# Altium schematic sheet 09 NET.SchDoc
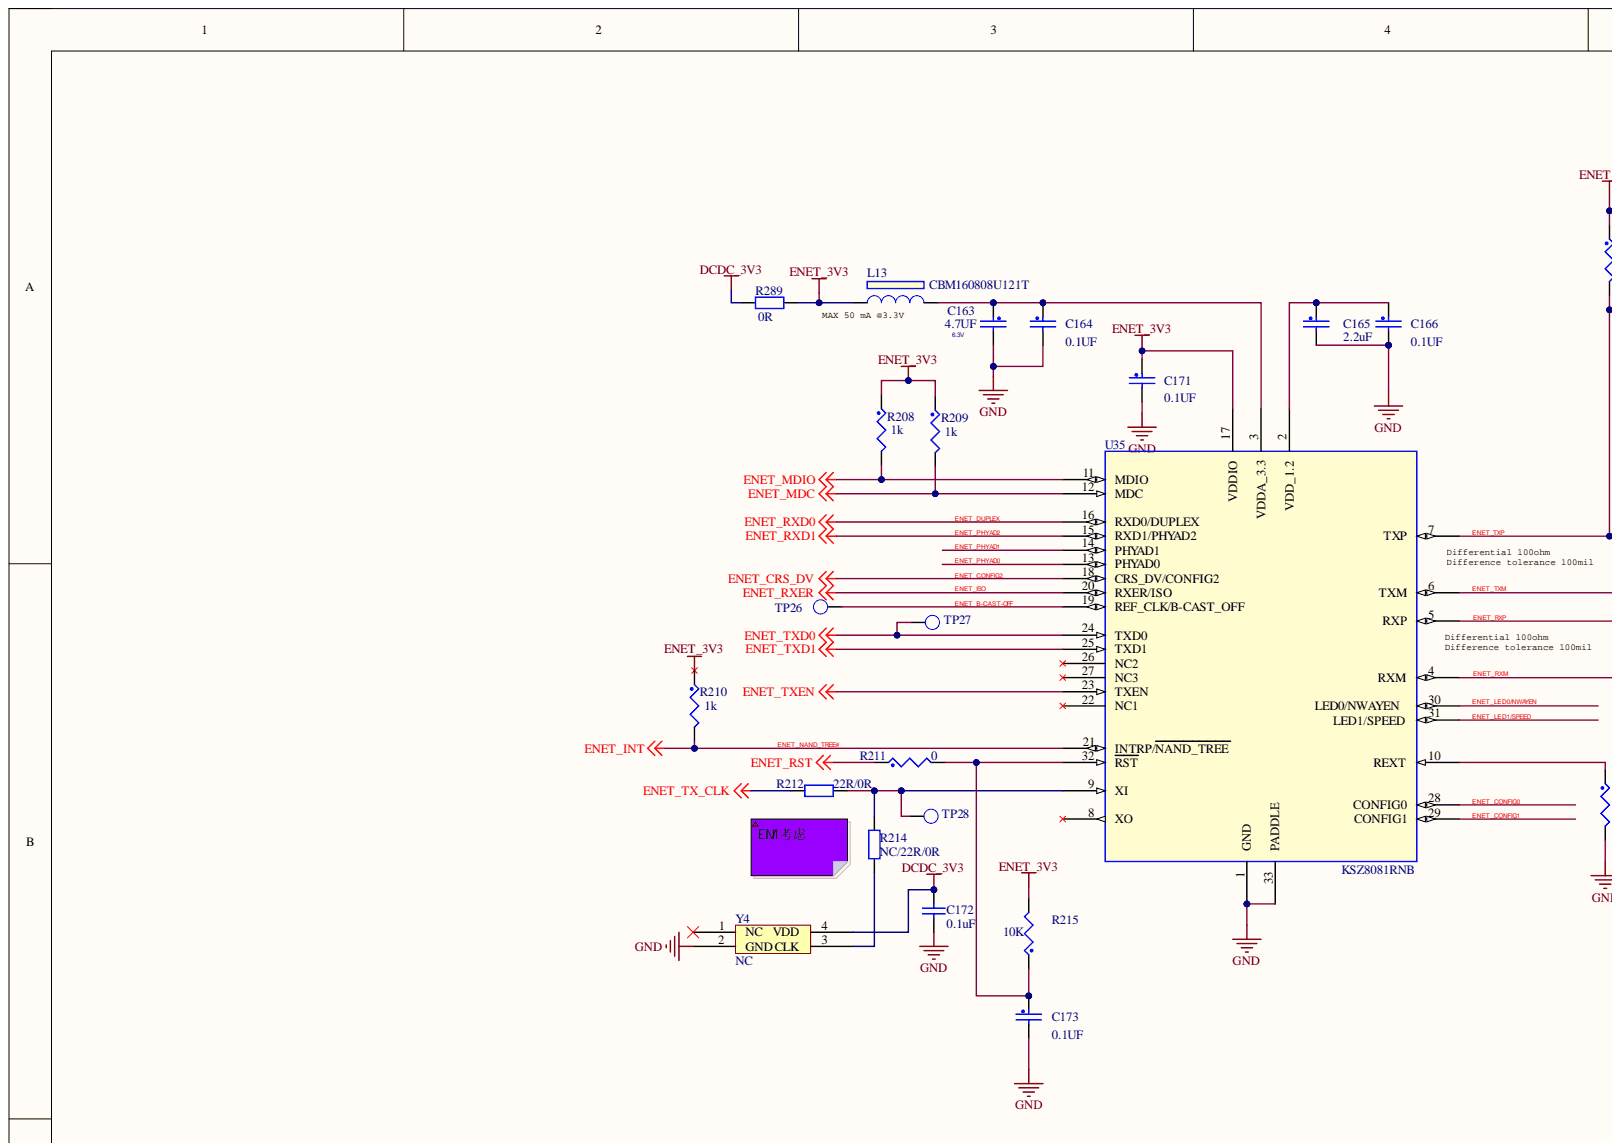
[diagram p0: 09 NET.SchDoc, top left]
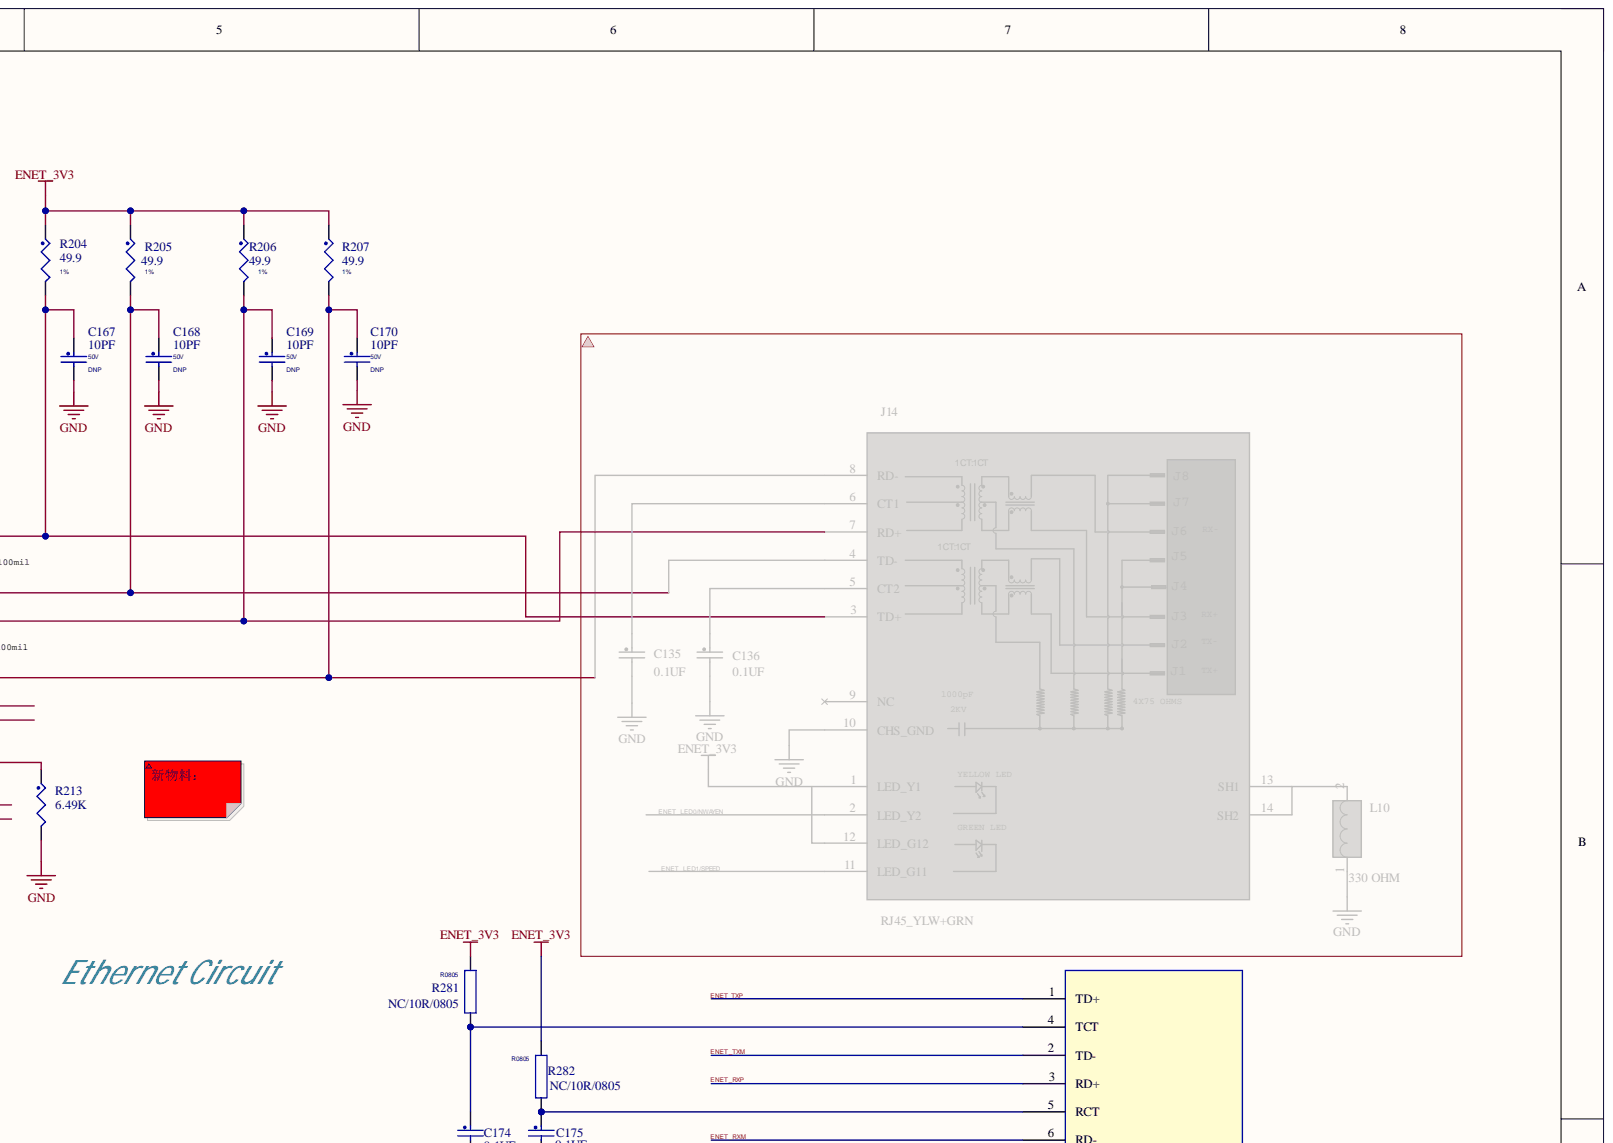
[diagram p1: 09 NET.SchDoc, top right]
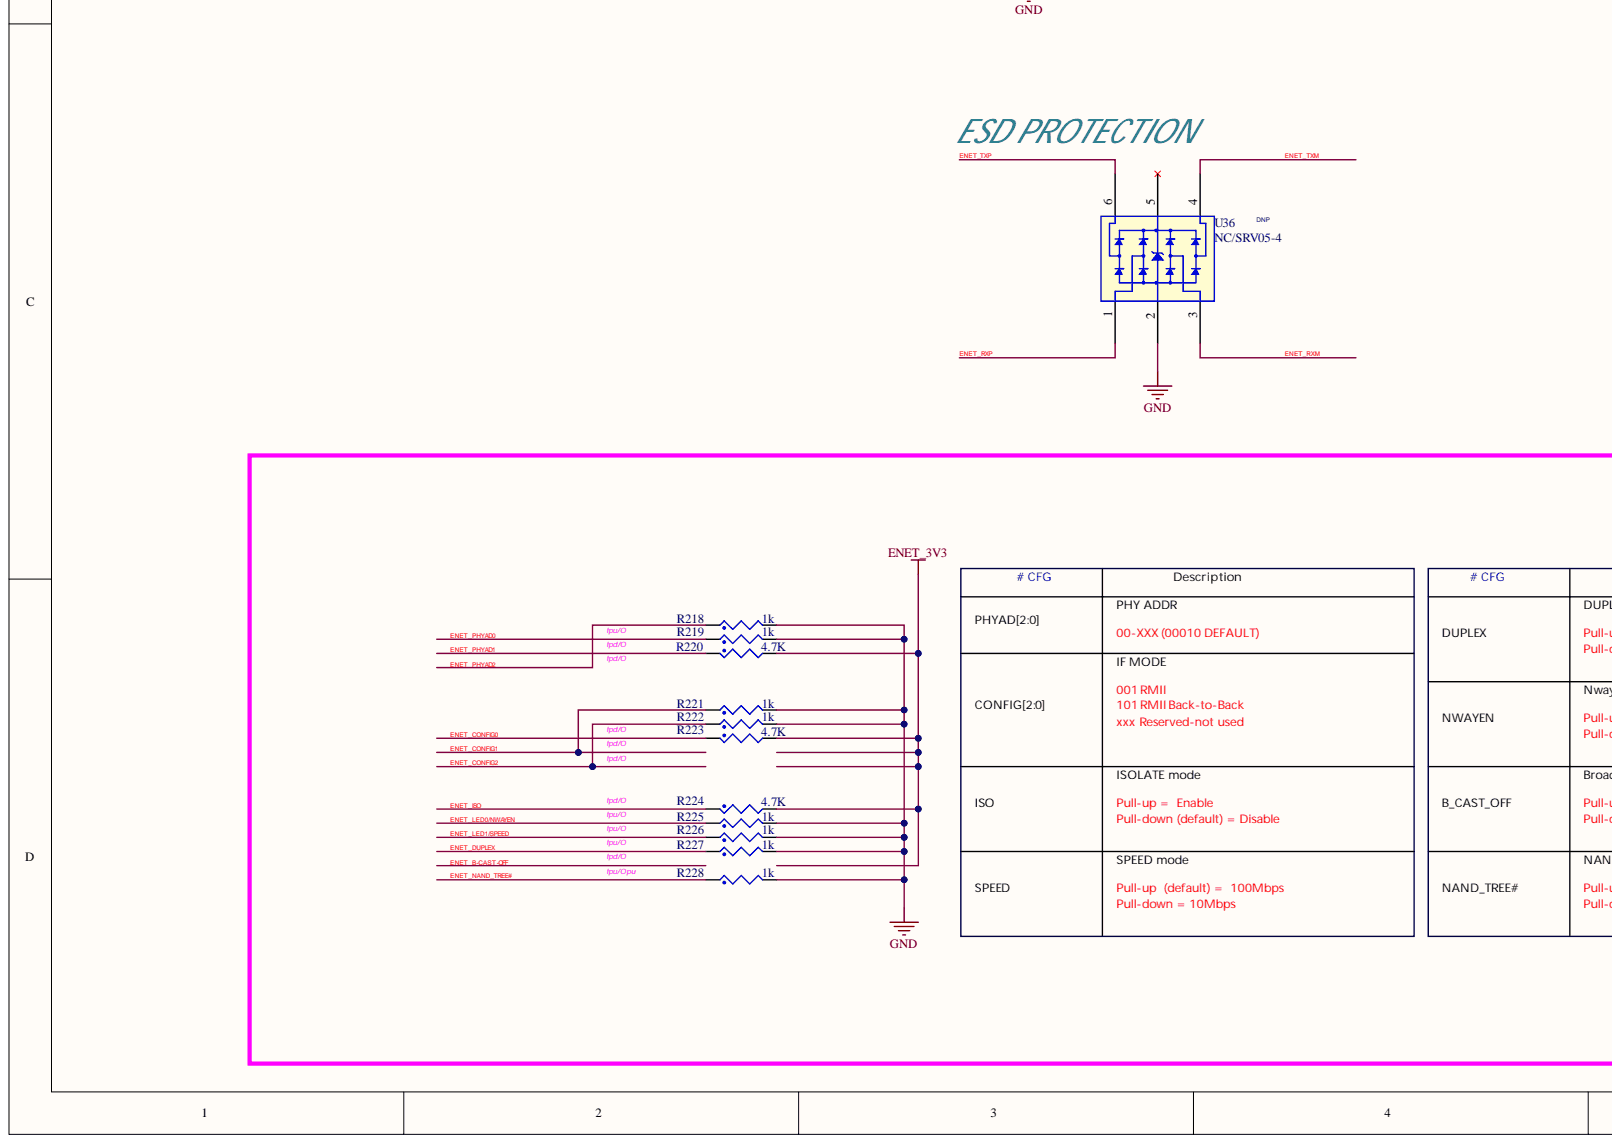
[diagram p2: 09 NET.SchDoc, bottom left]
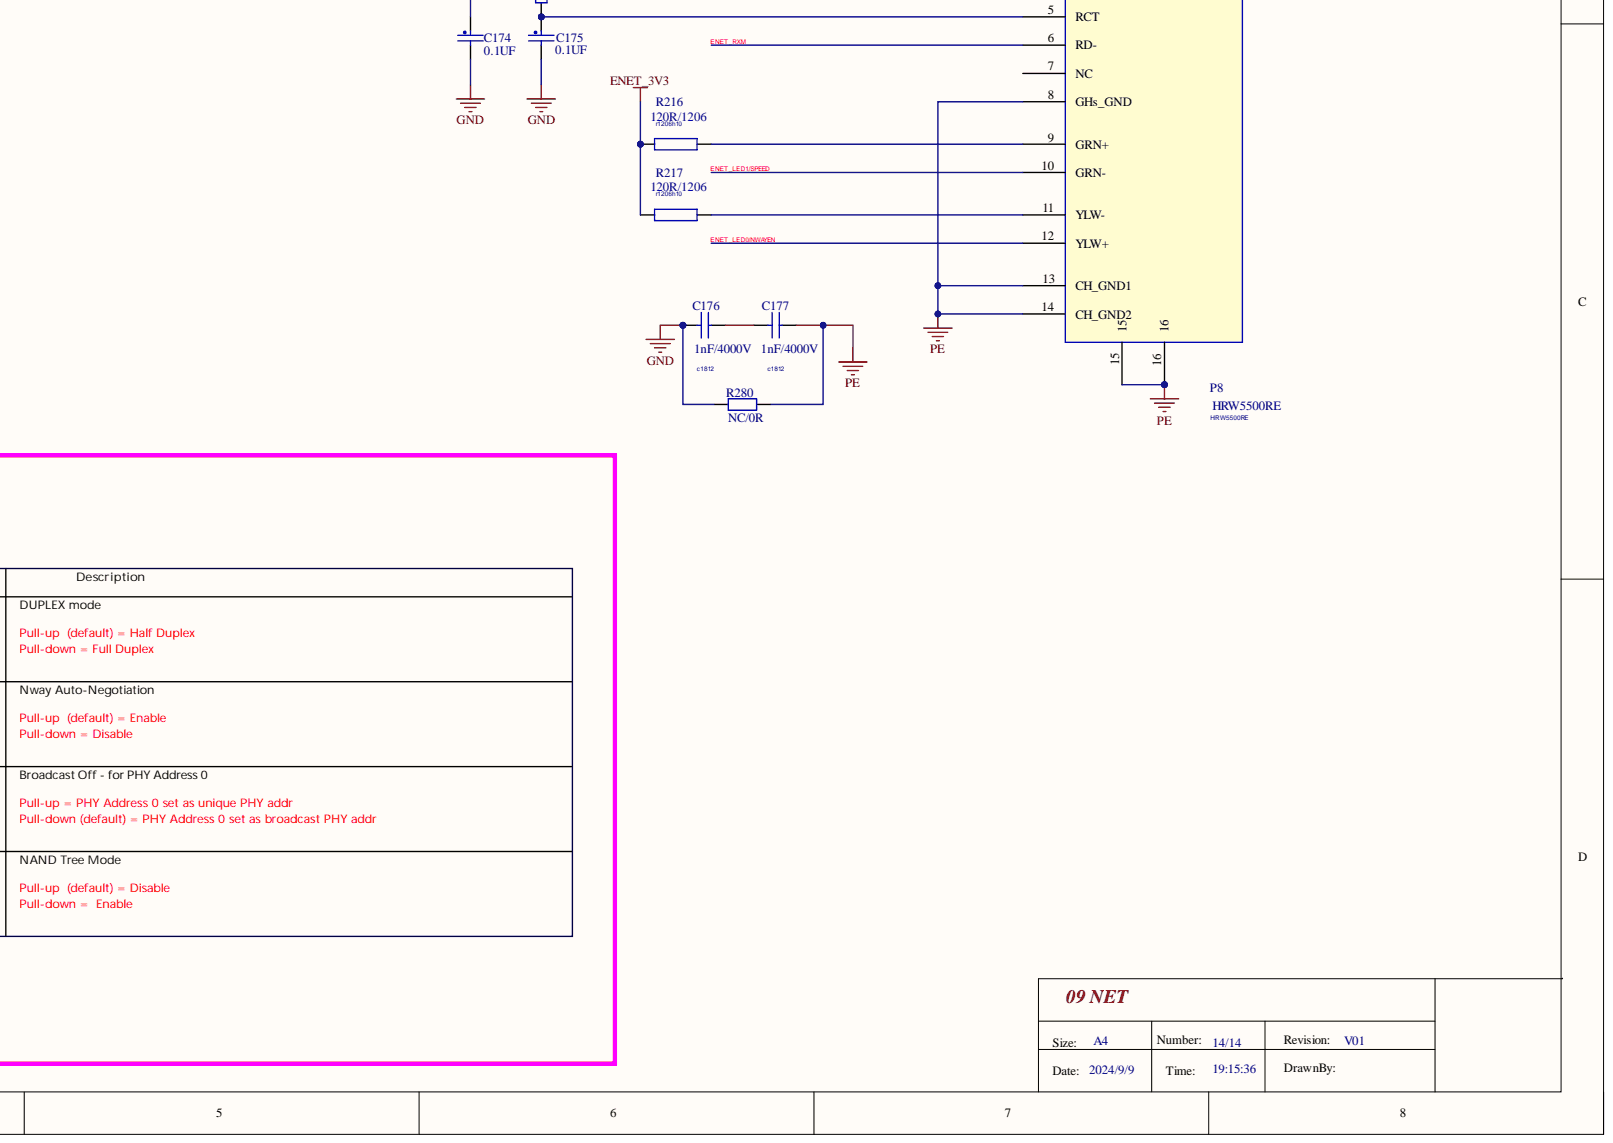
[diagram p3: 09 NET.SchDoc, bottom right]

## Parts
C163  4.7UF  VOLTAGE: 6.3V  [R0603]
C164  0.1UF  [C0603]
C165  2.2uF  [C0603]
C166  0.1UF  [C0603]
C167  10PF  ASSY_OPT: DNP  VOLTAGE: 50V  [C0603]
C168  10PF  ASSY_OPT: DNP  VOLTAGE: 50V  [C0603]
C169  10PF  ASSY_OPT: DNP  VOLTAGE: 50V  [C0603]
C170  10PF  ASSY_OPT: DNP  VOLTAGE: 50V  [C0603]
C171  0.1UF  [C0603]
C172  0.1uF  [C0603]
C173  0.1UF  [C0603]
C174  0.1UF  [C0603]
C175  0.1UF  [C0603]
C176  1nF/4000V  [c1812]
C177  1nF/4000V  [c1812]
L13  CBM160808U121T  [R0603]
P8  HRW5500RE  [HRW5500RE]
R204  49.9  TOL: 1%  [R0603]
R205  49.9  TOL: 1%  [R0603]
R206  49.9  TOL: 1%  [R0603]
R207  49.9  TOL: 1%  [R0603]
R208  1k  [R0603]
R209  1k  [R0603]
R210  1k  [R0603]
R211  0  [R0603]
R212  22R/0R  [R0603]
R213  6.49K  [R0603]
R214  NC/22R/0R  [R0603]
R215  10K  [R0603]
R216  120R/1206  [r1206h10]
R217  120R/1206  [r1206h10]
R218  1k  [R0603]
R219  1k  [R0603]
R220  4.7K  [R0603]
R221  1k  [R0603]
R222  1k  [R0603]
R223  4.7K  [R0603]
R224  4.7K  [R0603]
R225  1k  [R0603]
R226  1k  [R0603]
R227  1k  [R0603]
R228  1k  [R0603]
R280  NC/0R  [R1206]
R281  NC/10R/0805  [R0805]
R282  NC/10R/0805  [R0805]
R289  0R  [R0603]
TP26  TP_35MIL  [TP12]  (value not drawn)
TP27  TP_35MIL  [TP12]  (value not drawn)
TP28  TP_35MIL  [TP12]  (value not drawn)
U35  KSZ8081RNB  [QFN-32_KSZ8081RNB]
U36  NC/SRV05-4  ASSY_OPT: DNP  [SOT-23-6]
Y4  NC  [XTAL-3225]

## Nets
DCDC_3V3: C172.2 R289.1 Y4.4(VDD)
ENET_3V3: C171.1 L13.1 R204.1 R205.1 R206.1 R207.1 R208.1 R209.1 R210.1 R215.2 R216.1 R217.1 R220.2 R223.2 R224.2 R281.2 R282.2 R289.2 U35.17(VDDIO)
ENET_MDC: R209.2 U35.12(MDC)
ENET_MDIO: R208.2 U35.11(MDIO)
ENET_RST: R211.1
ENET_TX_CLK: R212.2
ENET_TXD0: TP27.1 U35.24(TXD0)
ENET_TXD1: U35.25(TXD1)
ENET_TXEN: U35.23(TXEN)
GND: C163.2 C164.2 C165.2 C166.2 C167.2 C168.2 C169.2 C170.2 C171.2 C172.1 C173.2 C174.2 C175.2 C176.2 R213.2 R218.2 R219.2 R221.2 R222.2 R225.2 R226.2 R227.2 R228.2 R280.1 U35.1(GND) U35.33(PADDLE) U36.2 Y4.2(GND)
PE: C177.1 P8.8(GHs_GND) P8.13(CH_GND1) P8.14(CH_GND2) P8.15 P8.16 R280.2
ENET_B-CAST-OFF: TP26.1 U35.19(REF_CLK/B-CAST_OFF)
ENET_CONFIG0: R223.1 U35.28(CONFIG0)
ENET_CONFIG1: R221.1 U35.29(CONFIG1)
ENET_CONFIG2: R222.1 U35.18(CRS_DV/CONFIG2)
ENET_DUPLEX: R227.1 U35.16(RXD0/DUPLEX)
ENET_ISO: R224.1 U35.20(RXER/ISO)
ENET_LED0/NWAYEN: P8.12(YLW+) R225.1 U35.30(LED0/NWAYEN)
ENET_LED1/SPEED: P8.10(GRN-) R226.1 U35.31(LED1/SPEED)
ENET_NAND_TREE#: R210.2 R228.1 U35.21(INTRP/NAND_TREE)
ENET_PHYAD0: R219.1 U35.13(PHYAD0)
ENET_PHYAD1: R220.1 U35.14(PHYAD1)
ENET_PHYAD2: R218.1 U35.15(RXD1/PHYAD2)
ENET_RXM: C170.1 P8.6(RD-) R207.2 U35.4(RXM) U36.3
ENET_RXP: C169.1 P8.3(RD+) R206.2 U35.5(RXP) U36.1
ENET_TXM: C168.1 P8.2(TD-) R205.2 U35.6(TXM) U36.4
ENET_TXP: C167.1 P8.1(TD+) R204.2 U35.7(TXP) U36.6
NetC163_1: C163.1 C164.1 L13.2 U35.3(VDDA_3.3)
NetC165_1: C165.1 C166.1 U35.2(VDD_1.2)
NetC173_1: C173.1 R211.2 R215.1 U35.32(RST)
NetC174_1: C174.1 P8.4(TCT) R281.1
NetC175_1: C175.1 P8.5(RCT) R282.1
NetC176_1: C176.1 C177.2
NetP8_9: P8.9(GRN+) R216.2
NetP8_11: P8.11(YLW-) R217.2
NetR212_1: R212.1 R214.1 TP28.1 U35.9(XI)
NetR213_1: R213.1 U35.10(REXT)
NetR214_2: R214.2 Y4.3(CLK)

Not connected: P8 7(NC); U35 8(XO) 22(NC1) 26(NC2) 27(NC3); U36 5; Y4 1

## Library data of the parts
C163 = CAP_NP: CAP CER 4.7UF 6.3V 20% X5R 0402; AGILE_PN: 150-78463; CAD_APPROVED: Yes; CLASS: DISCRETE; FPLIST: CC0402_25,CC0402_HD,0402_CC; MFG_NAME01: TDK; MFG_PN01: C1005X5R0J475M050BC; PCB Footprint: 0402_CC; Component Kind: Standard; HEIGHT: 0.65MM; ROHS: Yes; Tolerance: 20%; voltage rating: 6.3V
C164 C166 C171 C173-C175 = CAP_NP: CAP CER 0.1UF 10V 10% X5R 0402; AGILE_PN: 150-75634; CAD_APPROVED: Yes; CLASS: DISCRETE; FPLIST: CC0402_25,CC0402_HD,0402_CC; MFG_NAME01: KEMET; MFG_PN01: C0402C104K8PAC; PCB Footprint: CC0402_25; Component Kind: Standard; HEIGHT: 0.64MM; ROHS: Yes; Tolerance: 10%; VOLTAGE: 10V
C165 = CAP_NP: CAP CER 2.2uF 6.3V 10% X5R 0402; AGILE_PN: 150-79454; CAD_APPROVED: Yes; CLASS: DISCRETE; FPLIST: CC0402_25,CC0402_HD,0402_CC; MFG_NAME01: MURATA; MFG_PN01: GRM155R60J225KE95; PCB Footprint: CC0402_25; Component Kind: Standard; HEIGHT: 0.55MM; ROHS: Yes; Tolerance: 10%; VOLTAGE: 6.3V
C167-C170 = CAP_NP: CAP CER 10PF 50V 5% C0G 0402; AGILE_PN: 150-75265; CAD_APPROVED: YES; CLASS: DISCRETE; FPLIST: CC0402_25,CC0402_HD,0402_CC; MFG_NAME01: AVX; MFG_PN01: 04025A100JAT2A; PCB Footprint: CC0402_25; Component Kind: Standard; HEIGHT: 0.635MM; ROHS: Yes; TOL: 5%; Tolerance: 5%
C172 = Cap: MLCC 电容器; FootPoint: 0402; 厂商: 风华; 厂商编号: 0603B104K250NT; Component Kind: Standard; Library Name: 超超的封装库.IntLib
C176 C177 = CAP: Component Kind: Standard; PCB Footprint: c1812
L13 = INDUCTOR_FERRITE: IND 风华 CBM160808U121T 120/100MHz Ω ±25% 电流2 贴装; PART_TYPE: FERRITE\BEAD; AGILE_PN: 180-77771; CAD_APPROVED: Yes; CLASS: DISCRETE; FPLIST: IND_0402,0402_CC; MFG_NAME01: Murata; MFG_PN01: BLM15AG121SN1D; PCB Footprint: 0402_CC; Component Kind: Standard; HEIGHT: 0.55MM; ROHS: Yes; Tolerance: 25%
P8 = TJA1040T_47: Component Kind: Standard; PCB Footprint: HRW5500RE
R204-R207 = RES_Dup1: RES MF 49.9 OHM 1/10W 1% 0603; AGILE_PN: 470-75432; CAD_APPROVED: Yes; CLASS: DISCRETE; FPLIST: RC0603_OV,RC0603,0603_CC; MFG_NAME01: BOURNS; MFG_PN01: CR0603-FX-49R9ELF; PCB Footprint: RC0603_OV; Component Kind: Standard; HEIGHT: 0.55 MM; ROHS: Yes; Tolerance: 1%
R208-R210 R218 R219 R221 R222 R225-R228 = RES_Dup1: RES MF 1.0K 1/16W 5% 0402; AGILE_PN: 470-75555; CAD_APPROVED: Yes; CLASS: DISCRETE; FPLIST: RC0402_25,RC0402_HD,RC0402_X2,0402_CC; MFG_NAME01: VISHAY INTERTECHNOLOGY; MFG_PN01: CRCW04021K00JNED; PCB Footprint: 0402_CC; Component Kind: Standard; HEIGHT: 0.41mm; ROHS: Yes; Tolerance: 5%
R211 = RES_Dup1: RES MF ZERO OHM 1/16W 5% 0402; AGILE_PN: 470-75394; CAD_APPROVED: Yes; CLASS: DISCRETE; FPLIST: RC0402_25,RC0402_HD,RC0402_X2,0402_CC; MFG_NAME01: ROHM; MFG_PN01: MCR01MZPJ000; PCB Footprint: 0402_CC; Component Kind: Standard; HEIGHT: 0.45 MM; ROHS: Yes; Tolerance: 5%
R212 R214 = RES: 贴片电阻,22R-1/10W-0603-1%; 品牌: YAGEO; 型号规格: RC0603FR-0722RL; 焊接类型: SMT; Component Kind: Standard; ERP code: ELC.0284; Library Name: DH_Device.SchLib
R213 = RES_Dup1: RES MF 6.49K  1/16W 1% 0402; AGILE_PN: 470-30927; CAD_APPROVED: Yes; CLASS: DISCRETE; FPLIST: RC0402_25,RC0402_HD,0402_CC; MFG_NAME01: WALSIN TECHNOLOGY CORP.; MFG_PN01: WR04X6491FTL; PCB Footprint: 0402_CC; Component Kind: Standard; HEIGHT: 0.40 MM; ROHS: Yes; Tolerance: 1%
R215 = RES_Dup1: RES MF 10K 1/16W 5% 0402; AGILE_PN: 470-75557; CAD_APPROVED: Yes; CLASS: DISCRETE; FPLIST: RC0402_25,RC0402_HD,0402_CC; MFG_NAME01: VISHAY INTERTECHNOLOGY; MFG_PN01: CRCW040210K0JNED; PCB Footprint: 0402_CC; Component Kind: Standard; HEIGHT: 0.4 MM; ROHS: Yes; TOL: 5%; Tolerance: 5%
R216 R217 = R_0: Component Kind: Standard; PCB Footprint: r1206h10
R220 R223 R224 = RES_Dup1: RES MF 4.7K 1/16W 5% 0603; AGILE_PN: 470-75626; CAD_APPROVED: Yes; CLASS: DISCRETE; FPLIST: RC0402_25,RC0402_HD,0402_CC; MFG_NAME01: NIC COMPONENTS CORP; MFG_PN01: NRCL04J472TRF; PCB Footprint: RC0402_25; SIGNAL_MODEL: DEFAULT_RESISTOR_4700OHM_2_1; Component Kind: Standard; HEIGHT: 0.41mm; ROHS: Yes; TOL: 5%; Tolerance: 5%
R280 = RES: 片状电阻器 - 1/4W-75K±0.5%-50ppm; ERP code: ADQELR0051; Component Kind: Standard; Library Name: Device_Schlib.SCHLIB
R281 R282 = R_2: Component Kind: Standard; PCB Footprint: R0805
R289 = Res: 电阻器; 厂: Samsung; Component Kind: Standard; Library Name: 超超的封装库.IntLib
TP26-TP28 = T POINT R: TEST POINT PAD .035 SMT, no part to order; AGILE_PN: 510-75618; CAD_APPROVED: Yes; CLASS: IC; PCB Footprint: testpoint_35mil; Component Kind: Standard; HEIGHT: 0.1MM; ROHS: Yes
U35 = KSZ8081RNB_32P: IC XCVR 10/100M EPHY 3.3V QFN32; AGILE_PN: 312-81089; CAD_APPROVED: Yes; MFG_NAME01: Micrel; MFG_PN01: KSZ8081RNBIA; PCB Footprint: qfn32_0p5_ep3p3; CLASS: IC; Component Kind: Standard; HEIGHT: 0.9MM; ROHS: Yes
U36 = SRV05-4: DIODE TVS ARRAY 12A 5V 300W SOT23_S6; AGILE_PN: 480-75205; CAD_APPROVED: Yes; CLASS: IC; MFG_NAME01: SEMTECH CORP; MFG_PN01: SRV05-4.TCT; Component Kind: Standard; HEIGHT: 1.45mm; PCB Footprint: SOT23_S6; ROHS: Yes
Y4 = CLOCK: oscillator; 厂商: EPSON; 厂商编号: SG-310SCF-50MHZ-50PPM; Component Kind: Standard; Library Name: ZKM1V03.IntLib
